# Altium schematic sheet pi.SchDoc
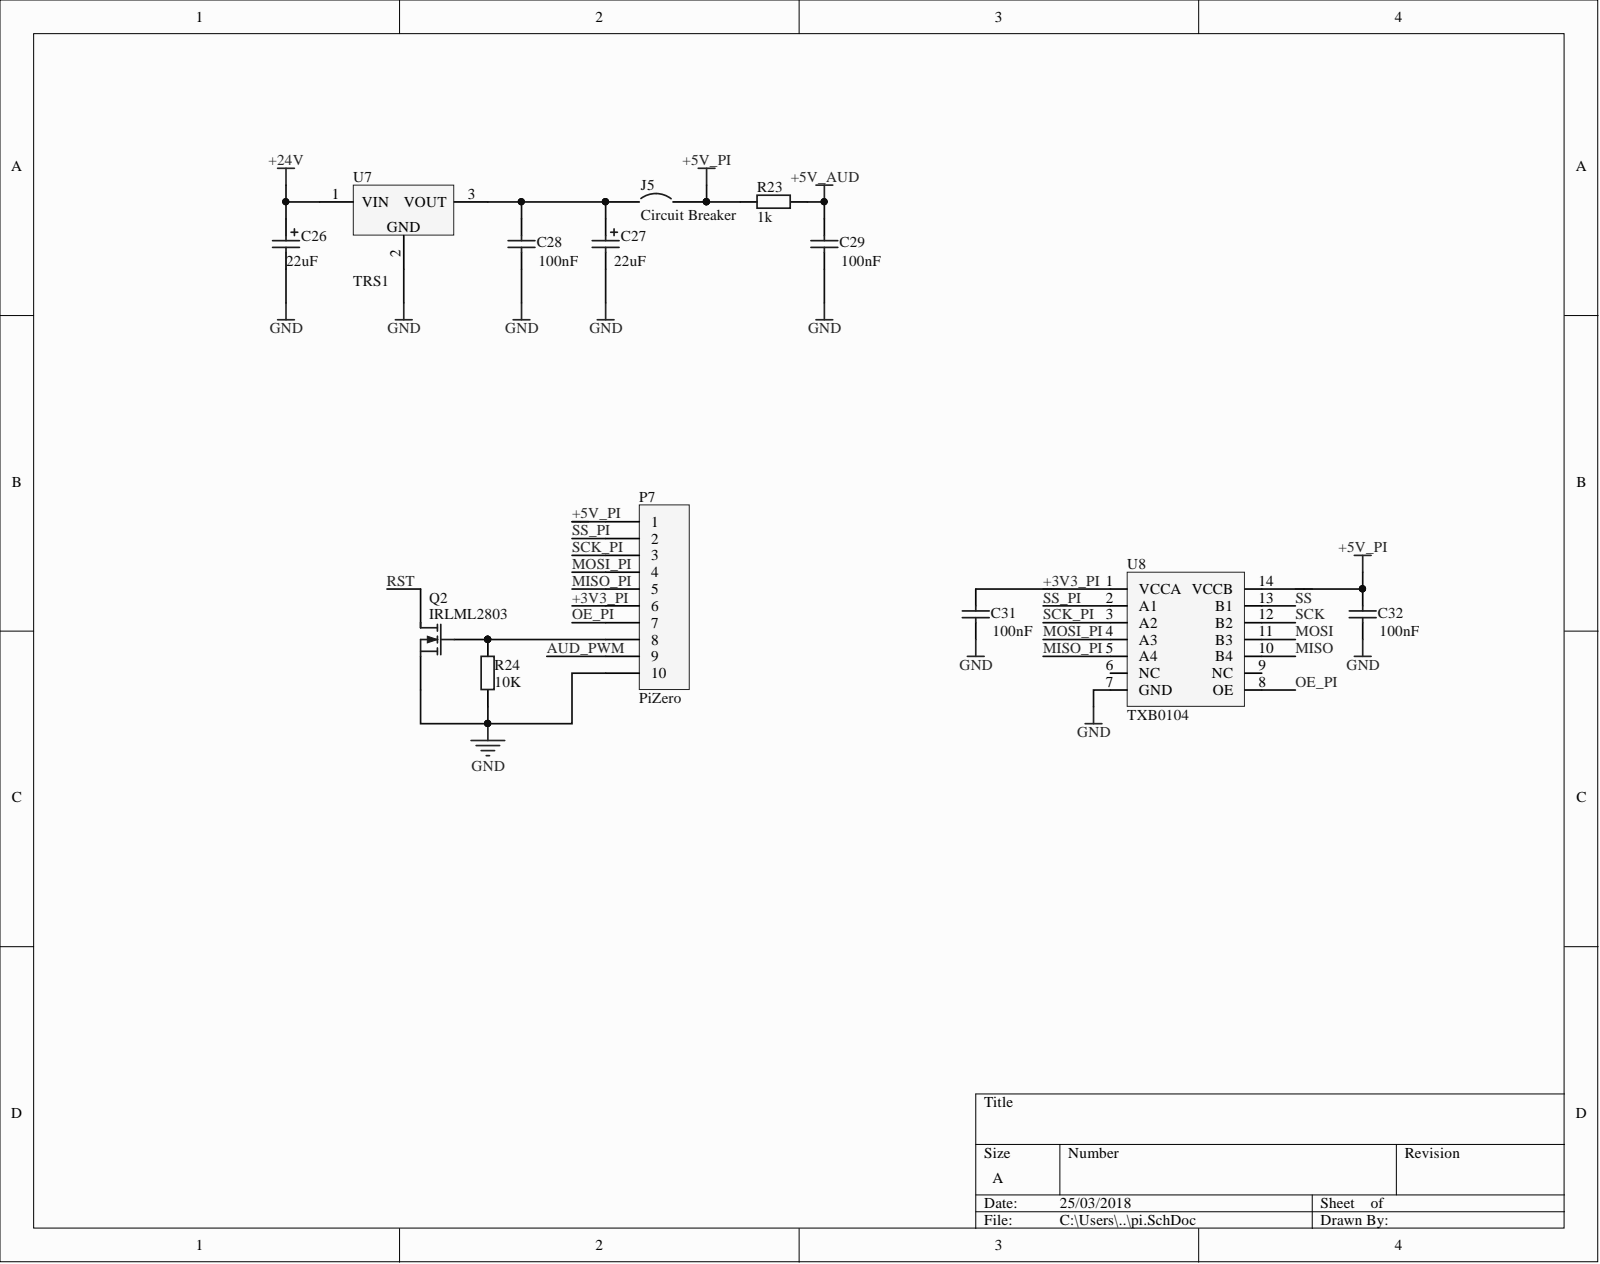
[diagram p0: pi.SchDoc]

## Parts
C26  value 22uF  [EEEFK1H]  (hidden comment: Cap Pol2)
C27  value 22uF  [EEEFK1H]  (hidden comment: Cap Pol2)
C28  value 100nF  [6-0805_M]  (hidden comment: Cap)
C29  value 100nF  [6-0805_M]  (hidden comment: Cap)
C31  value 100nF  [6-0805_M]  (hidden comment: Cap)
C32  value 100nF  [6-0805_M]  (hidden comment: Cap)
J5  Circuit Breaker  [SOLDER_JUMPER_24mil]
P7  PiZero  [HDR1X10]
Q2  IRLML2803  [SOT-23_N]
R23  value 1k  [6-0805_M]  (hidden comment: Res2)
R24  value 10K  [6-0805_M]  (hidden comment: Res2)
U7  TRS1  [TSR1-2450]
U8  TXB0104  [SOIC-14]

## Nets
+5V_AUD: C29.2 R23.2
+24V: C26.1 U7.1
GND: C26.2 C27.2 C28.1 C29.1 C31.1 C32.1 P7.10 Q2.3 R24.2 U7.2 U8.7(GND)
+3V3_PI: C31.2 P7.6 U8.1(VCCA)
+5V_PI: C32.2 J5.2 P7.1 R23.1 U8.14(VCCB)
AUD_PWM: P7.9
MISO: U8.10(B4)
MISO_PI: P7.5 U8.5(A4)
MOSI: U8.11(B3)
MOSI_PI: P7.4 U8.4(A3)
OE_PI: P7.7 U8.8(OE)
RST: Q2.1
~{SS}: U8.13(B1)
~{SS}_PI: P7.2 U8.2(A1)
SCK: U8.12(B2)
SCK_PI: P7.3 U8.3(A2)
NetC27_1: C27.1 C28.2 J5.1 U7.3
NetP7_8: P7.8 Q2.2 R24.1

Not connected: U8 6(NC) 9(NC)

## Library data of the parts
C26 C27 = Cap Pol2: Polarized Capacitor (Axial); Component Kind: Standard; LatestRevisionDate: 17-Jul-2002; LatestRevisionNote: Re-released for DXP Platform.; Library Name: Miscellaneous Devices.IntLib; PackageDescription: Polarized Capacitor; 2 Leads; PackageReference: POLAR0.8; Published: 8-Jun-2000; Signal Integrity: Cap Pol; Simulation: CAP; Publisher: Altium Limited
C28 C29 C31 C32 = Cap: Capacitor; Component Kind: Standard; LatestRevisionDate: 17-Jul-2002; LatestRevisionNote: Re-released for DXP Platform.; Library Name: Miscellaneous Devices.IntLib; PackageDescription: Radial Cap, Thru-Hole; 2 Leads; 0.3 in Pin Spacing; PackageReference: RAD-0.3; Published: 8-Jun-2000; Signal Integrity: Cap; Simulation: CAP; Publisher: Altium Limited
P7 = Header 10: Header, 10-Pin; Component Kind: Standard; LatestRevisionDate: 17-Jul-2002; LatestRevisionNote: Re-released for DXP Platform.; Library Name: Miscellaneous Connectors.IntLib; Signal Integrity: Connector; Publisher: Altium Limited
Q2 = MOSFET-N: N-Channel MOSFET; Component Kind: Standard; LatestRevisionDate: 17-Jul-2002; LatestRevisionNote: Re-released for DXP Platform.; Library Name: Miscellaneous Devices.IntLib; PackageDescription: TO, Flat Index; 3 In-Line, Axial Leads; Body Dia. 4.6mm; Lead Dia. 0.5 mm (max); PackageReference: E3; PackageVersion: 1995/1996; Published: 8-Jun-2000; Simulation: NMOS; Publisher: Altium Limited
R23 R24 = Res2: Resistor; Component Kind: Standard; LatestRevisionDate: 17-Jul-2002; LatestRevisionNote: Re-released for DXP Platform.; Library Name: Miscellaneous Devices.IntLib; PackageDescription: Axial Device, Thru-Hole; 2 Leads; 0.4 in Pin Spacing; PackageReference: AXIAL-0.4; Published: 8-Jun-2000; Signal Integrity: Res; Simulation: RESISTOR; Publisher: Altium Limited
U7 = TSR1-2450: TSR1-2450 DC/DC Step-Down Converter; Component Kind: Standard; Library Name: clusterlib.SchLib
U8 = TXB0104: TXB0104 4bit Bidirectional Voltage-Level Translator; Component Kind: Standard; Library Name: clusterlib.SchLib
J5 = Circuit Breaker: Component Kind: Standard; Description: Circuit Breaker; LatestRevisionDate: 17-Jul-2002; LatestRevisionNote: Re-released for DXP Platform.; Library Name: Miscellaneous Devices.IntLib; PackageDescription: Switch; 2 Leads; PackageReference: SPST-2; Published: 24-Mar-1999; Publisher: Altium Limited
